# Altium schematic sheet Sheet1.SchDoc(Sheet1)
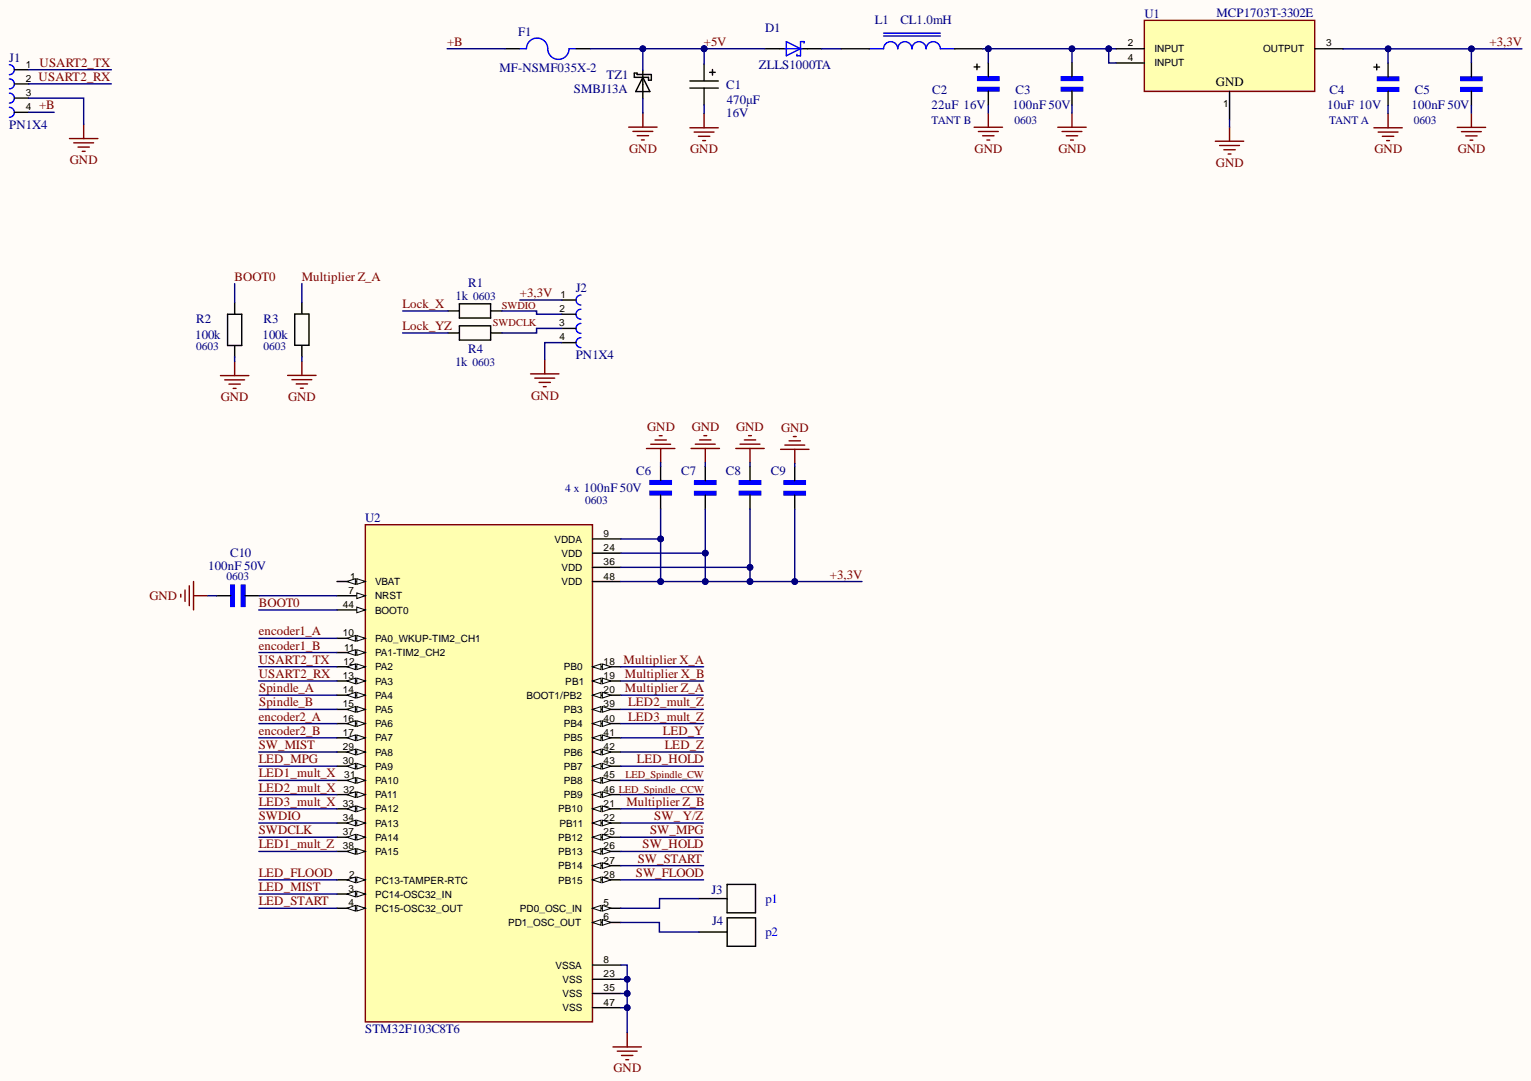
[diagram p0: Sheet1.SchDoc]

## Parts
C1  470μF  Voltage: 16V  [CAP 8x12]
C2  22uF  16V  value 22uF  Case: TANT B  Voltage: 16V  [SMD 1210 CAP TANT YelloW]
C3  100nF 50V  Case: 0603  [SMD 0603 CAP]
C4  10uF  10V  value 10uF  Voltage: 10V  [SMD 1206 CAP TANT Yellow]
C5  100nF 50V  Case: 0603  [SMD 0603 CAP]
C6  100nF 50V  [SMD 0603 CAP]
C7  100nF 50V  [SMD 0603 CAP]
C8  100nF 50V  [SMD 0603 CAP]
C9  100nF 50V  [SMD 0603 CAP]
C10  100nF 50V  Case: 0603  [SMD 0603 CAP]
D1  ZLLS1000TA  [SOT-23-3]
F1  MF-NSMF035X-2  [SMD 1206 FUSE]
J1  PN1X4  [PN1X4]
J2  PN1X4  [PN1X4]
J3  p1  [Wire-2]
J4  p2  [Wire-2]
L1  CL1.0mH  [DRH104R]
R1  1k  Case: 0603  [SMD 0603]
R2  100k  Case: 0603  [SMD 0603]
R3  100k  Case: 0603  [SMD 0603]
R4  1k  Case: 0603  [SMD 0603]
TZ1  SMBJ13A  [DO-214AA (SMB)]
U1  MCP1703T-3302E  [SOT-89(MB)]
U2  STM32F103C8T6  [QFP50P900X900X160-48N]

## Nets
GND: C1.2 C2.2 C3.1 C4.2 C5.1 C6.2 C7.2 C8.2 C9.2 C10.1 J1.3 J2.4 R2.2 R3.2 TZ1.A U1.1 U2.8(VSSA) U2.23(VSS) U2.35(VSS) U2.47(VSS)
+3,3V: C4.1 C5.2 C6.1 C7.1 C8.1 C9.1 J2.1 U1.3(OUTPUT) U2.9(VDDA) U2.24(VDD) U2.36(VDD) U2.48(VDD)
+5V: C1.1 D1.3 F1.2 TZ1.C
+B: F1.1 J1.4
BOOT0: R2.1 U2.44(BOOT0)
encoder1_A: U2.10(PA0_WKUP-TIM2_CH1)
encoder1_B: U2.11(PA1-TIM2_CH2)
encoder2_A: U2.16(PA6)
encoder2_B: U2.17(PA7)
LED1_mult_X: U2.31(PA10)
LED1_mult_Z: U2.38(PA15)
LED2_mult_X: U2.32(PA11)
LED2_mult_Z: U2.39(PB3)
LED3_mult_X: U2.33(PA12)
LED3_mult_Z: U2.40(PB4)
LED_FLOOD: U2.2(PC13-TAMPER-RTC)
LED_HOLD: U2.43(PB7)
LED_MIST: U2.3(PC14-OSC32_IN)
LED_MPG: U2.30(PA9)
LED_Spindle_CCW: U2.46(PB9)
LED_Spindle_CW: U2.45(PB8)
LED_START: U2.4(PC15-OSC32_OUT)
LED_Y: U2.41(PB5)
LED_Z: U2.42(PB6)
Lock_X: R1.2
Lock_YZ: R4.2
Multiplier X_A: U2.18(PB0)
Multiplier X_B: U2.19(PB1)
Multiplier Z_A: R3.1 U2.20(BOOT1/PB2)
Multiplier Z_B: U2.21(PB10)
Spindle_A: U2.14(PA4)
Spindle_B: U2.15(PA5)
SW_ Y/Z: U2.22(PB11)
SW_FLOOD: U2.28(PB15)
SW_HOLD: U2.26(PB13)
SW_MIST: U2.29(PA8)
SW_MPG: U2.25(PB12)
SW_START: U2.27(PB14)
SWDCLK: J2.3 R4.1 U2.37(PA14)
SWDIO: J2.2 R1.1 U2.34(PA13)
USART2_RX: J1.2 U2.13(PA3)
USART2_TX: J1.1 U2.12(PA2)
NetC2_1: C2.1 C3.2 L1.2 U1.2(INPUT) U1.4(INPUT)
NetC10_2: C10.2 U2.7(NRST)
NetD1_1: D1.1 L1.1
NetJ3_1: J3.1 U2.5(PD0_OSC_IN)
NetJ4_1: J4.1 U2.6(PD1_OSC_OUT)

Not connected: U2 1(VBAT)

## Library data of the parts
C1 = CAP Aluminium: Manufacturer: RUBYCON CORP; Tipe: Low ESR; Case: 8x11,5x3,5; Component Kind: Standard; HelpURL: https://store.comet.bg/Catalogue/Product/5165584/; Library Name: CAN_Library.IntLib; Temperature: -55...+105°C; Value: 470μF
C2 = Cap Tant 220uF 10V Case E: TANT B SMD 22uF 16V 293D226X9016B2TE3; Manufacturer: VISHAY; Component Kind: Standard; HalpURL: https://store.comet.bg/Catalogue/Product/49334/; Library Name: CAN_Library.IntLib
C3 C5 C7-C10 = CL32B106KLJNNNE: CL10B104KB85PNC; Manufacturer: SAMSUNG ELECTRO-MECHANICS; Component Kind: Standard; HelpURL: https://store.comet.bg/Catalogue/Product/36844/; Library Name: CAN_Library.IntLib; Model: CL32B106KLJNNNE; Value: 100nF 50V
  C7-C9: Case: 0603
C4 = Cap Tant 220uF 10V Case E: TANT A SMD 10uF 10V TAJA106K010RNJ; Manufacturer: VISHAY; Case: TANT A; Component Kind: Standard; HalpURL: https://store.comet.bg/Catalogue/Product/15617/; Library Name: CAN_Library.IntLib; Value: 10uF
C6 = CL32B106KLJNNNE: CL10B104KB85PNC; Manufacturer: SAMSUNG ELECTRO-MECHANICS; Text: 4 x; Case: 0603; Component Kind: Standard; HelpURL: https://store.comet.bg/Catalogue/Product/36844/; Library Name: CAN_Library.IntLib; Model: CL32B106KLJNNNE; Value: 100nF 50V
D1 = ZLLS1000TA: Schottky Diode, Vr=40V, If=1.16A, Uf=0.43V/1.0A, Ifsm=22A/<100us, Ir=5uA typ, trr=5.0ns typ; Manufacturer: DIODES/ZETEX; Case: SOT23; Component Kind: Standard; HelpURL: https://store.comet.bg/Catalogue/Product/5164587/; Library Name: CAN_Library.IntLib; Value: ZLLS1000TA
F1 = Fuse: PTC Resettable Fuse, Ihold 0.35A, 16V, SMD 1206; MF: BOURNS; Case: 1206; Component Kind: Standard; Library Name: CAN_Library.IntLib; Value: MF-NSMF035X-2
J1 J2 = PN1X4: Single Row / Straight / Without Shroud Female header Female 4 @ Pin header, socket _Si...; MF: WÃ¼rth Elektronik; MP: 61300711821; Value: Wire; Availability: Unavailable; Component Kind: Standard; Library Name: CAN_Library.IntLib; Package: None; Price: None; SnapEDA_Link: https://www.snapeda.com/parts/61300711821/W%C3%BCrth%20Elektronik/view-part/2704590/?ref=snap
J3 J4 = Wire: Case: 0,8mm; Component Kind: Standard; Description: Wire; Library Name: CAN_Library.IntLib; Value: Wire
L1 = DRH104R: CL1.0mH SDI104R (DRH104R);     2.959 ohm, 0.48A, 20%; MF: CORE MASTER; MP: SDI 104R - 102M; Case: DRH104R; Component Kind: Standard; Library Name: CAN_Library.IntLib; Value: CL1.0mH
R1-R4 = Resistor_2: RES; Component Kind: Standard; HelpURL: https://store.comet.bg/Catalogue/RES/Resistors/#eyJpcHAiOiIxMDAiLCJtIjoiIn0%3D; Library Name: CAN_Library.IntLib
  R1 R4: Value: 1k
  R2 R3: Value: 100k
TZ1 = SMBJ18A: Transient Voltage Suppressors, Uni-directional, 600W, UBRmin14.4V@1mA; Manufacturer: Vishay General Semiconductor; Case: DO-214AA SMB; Component Kind: Standard; HelpURL: https://store.comet.bg/Catalogue/Product/9448/; Library Name: CAN_Library.IntLib; Value: SMBJ13A
U1 = MCP1703T-3302E/MB: 3.3V±2% 250mA, LDO 0.725V, Vinmax=16V, linear voltage regulator; MF: Microchip; Case: SOT-89; Component Kind: Standard; Library Name: CAN_Library.IntLib; Value: MCP1703T-3302E
U2 = STM32F103C8T6: ARMÂ® CortexÂ®-M3 STM32F1 Microcontroller IC 32-Bit 72MHz 64KB (64K x 8) FLASH 48-LQFP (7x7); MF: STMicroelectronics; Availability: In Stock; Check_prices: https://www.snapeda.com/parts/STM32F103C8T6/STMicroelectronics/view-part/?ref=eda; Component Kind: Standard; Library Name: CAN_Library.IntLib; MP: STM32F103C8T6; Package: LQFP-48 STMicroelectronics; Price: None; Purchase-URL: https://pricing.snapeda.com/search/part/STM32F103C8T6/?ref=eda; SnapEDA_Link: https://www.snapeda.com/parts/STM32F103C8T6/STMicroelectronics/view-part/?ref=snap; Value: STM32F103C8T6
